# Altium schematic sheet ArduinoDevelopBoard-v2.SchDoc
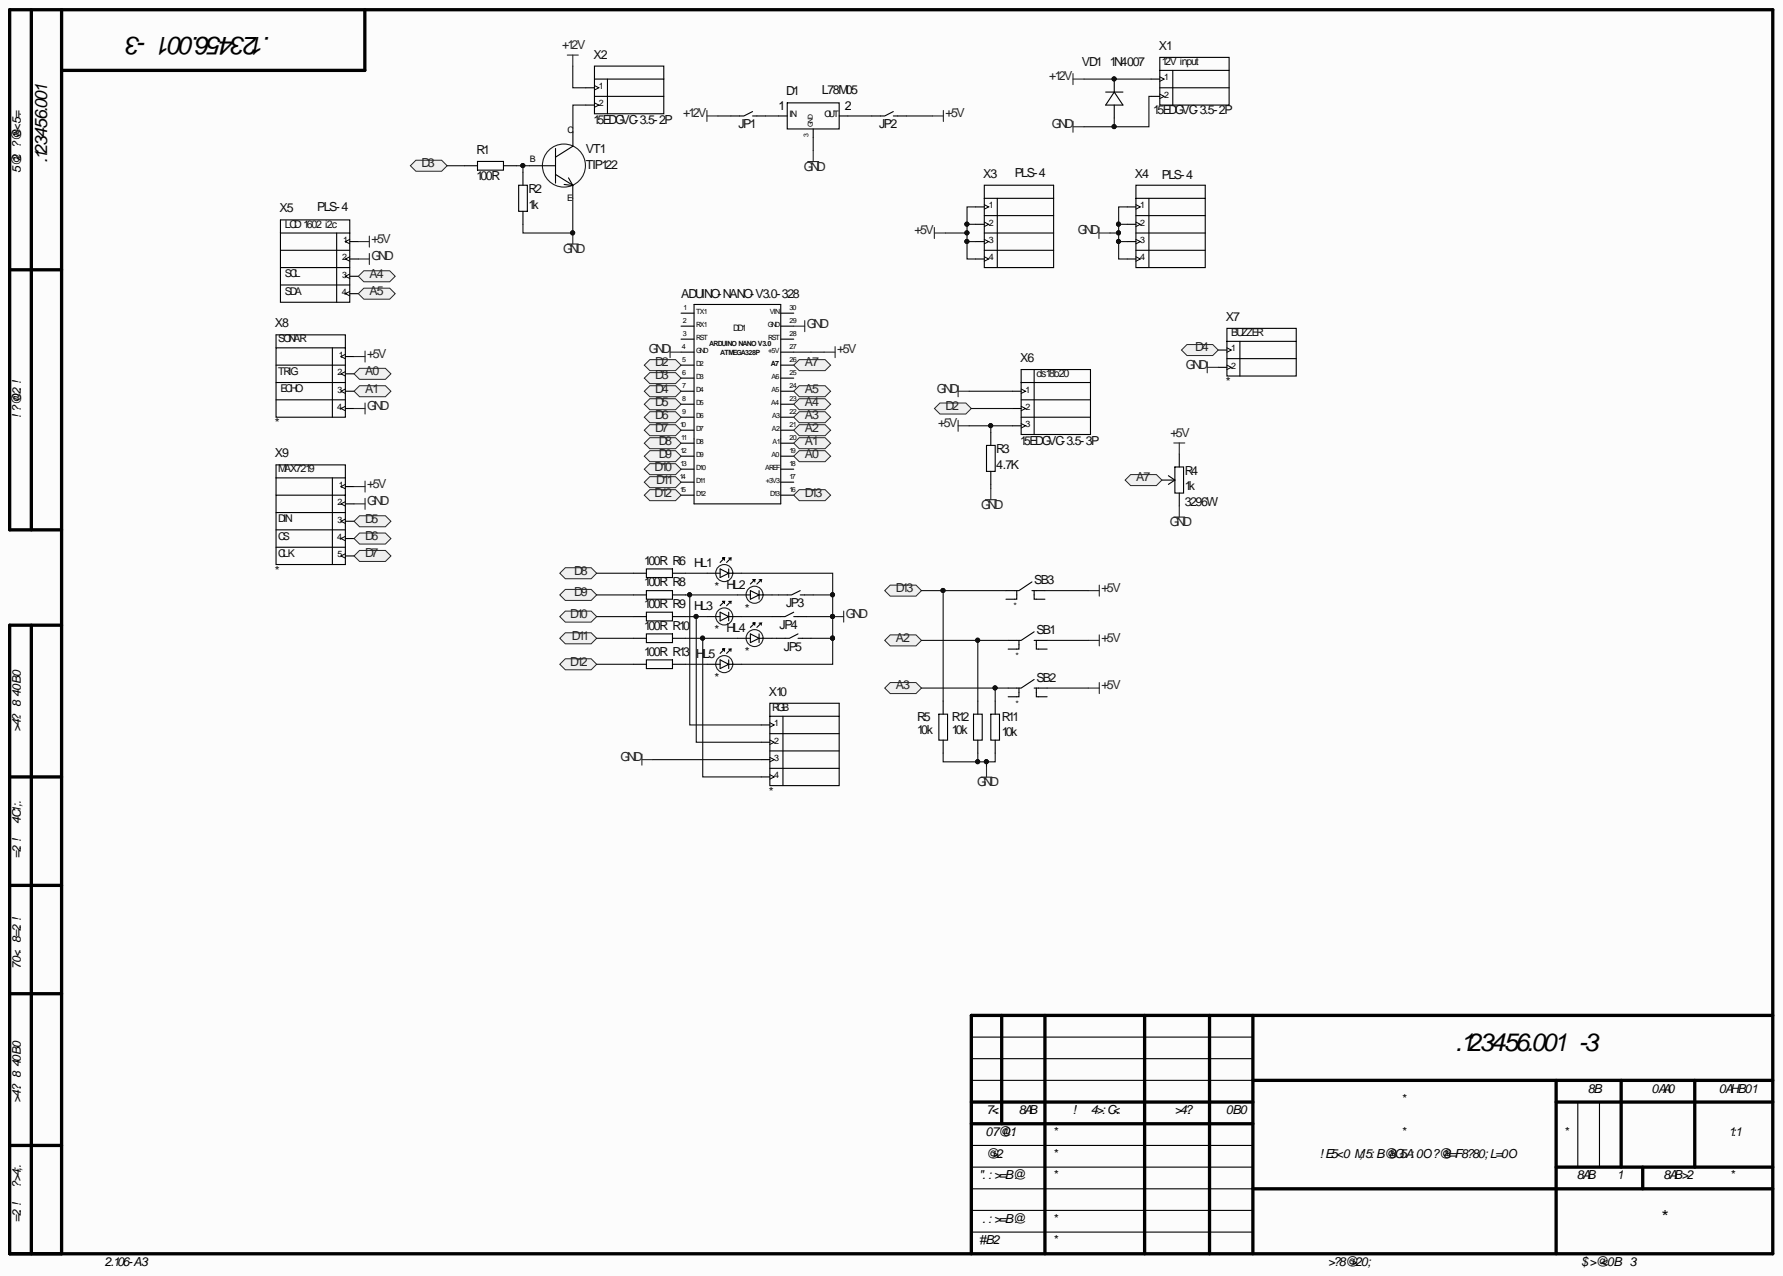
[diagram p0: ArduinoDevelopBoard-v2.SchDoc]

## Parts
D1  L78M05  [D-PAK]
DD1  ADUINO-NANO-V3.0-328  [ARDUINO_NANO_V3.0]
HL1  (no value)  [Led Diode 5mm]
HL2  (no value)  [Led Diode 5mm]
HL3  (no value)  [Led Diode 5mm]
HL4  (no value)  [Led Diode 5mm]
HL5  (no value)  [Led Diode 5mm]
JP1  Jumper  [PLS-2]  (value not drawn)
JP2  Jumper  [PLS-2]  (value not drawn)
JP3  Jumper  [PLS-2]  (value not drawn)
JP4  Jumper  [PLS-2]  (value not drawn)
JP5  Jumper  [PLS-2]  (value not drawn)
R1  100R  [Resistor-0.25W]
R2  1k  [Resistor-0.25W]
R3  4.7K  [Resistor-0.25W]
R4  1k  [3296W_Resistor]
R5  10k  [Resistor-0.25W]
R6  100R  [Resistor-0.25W]
R8  100R  [Resistor-0.25W]
R9  100R  [Resistor-0.25W]
R10  100R  [Resistor-0.25W]
R11  10k  [Resistor-0.25W]
R12  10k  [Resistor-0.25W]
R13  100R  [Resistor-0.25W]
SB1  (no value)  [KLS7-TS6601-5.0-180]
SB2  (no value)  [KLS7-TS6601-5.0-180]
SB3  (no value)  [KLS7-TS6601-5.0-180]
VD1  1N4007  [Diode (DO41)]
VT1  TIP122  [TO220]
X1  15EDGVC-3.5-2P  [15EDGVC-3.5-2P]
X2  15EDGVC-3.5-2P  [15EDGVC-3.5-2P]
X3  PLS-4  [PLS-4]
X4  PLS-4  [PLS-4]
X5  PLS-4  [PLS-4]
X6  15EDGVC-3.5-3P  [PLS-3]
X7  (no value)  [PLS-2]
X8  (no value)  [PLS-4]
X9  (no value)  [PLS-5]
X10  (no value)  [PLS-4]

## Ports
A0, A1, A2, A3, A4, A5, A7, D2, D3, D4, D5, D6, D7, D8, D9, D10, D11, D12, D13

## Nets
+5V: DD1.27(+5V) JP2.2 R3.2 R4.3 SB1.3 SB2.3 SB3.3 X3.1 X3.2 X3.3 X3.4 X5.1 X6.3 X8.1 X9.1
+12V: JP1.1 VD1.2 X1.1 X2.1
GND: D1.3 DD1.4(GND) DD1.29(GND) HL1.2 HL5.2 JP3.2 JP4.2 JP5.2 R2.2 R3.1 R4.1 R5.1 R11.1 R12.1 VD1.1 VT1.E X1.2 X4.1 X4.2 X4.3 X4.4 X5.2 X6.1 X7.2 X8.4 X9.2 X10.3
A0: DD1.19(A0) X8.2 ; port A0
A1: DD1.20(A1) X8.3 ; port A1
A2: DD1.21(A2) R12.2 SB1.1 ; port A2
A3: DD1.22(A3) R11.2 SB2.1 ; port A3
A4: DD1.23(A4) X5.3 ; port A4
A5: DD1.24(A5) X5.4 ; port A5
A7: DD1.26(A7) R4.2 ; port A7
D2: DD1.5(D2) X6.2 ; port D2
D3: DD1.6(D3) R1.2 ; port D3
D4: DD1.7(D4) X7.1 ; port D4
D5: DD1.8(D5) X9.3 ; port D5
D6: DD1.9(D6) X9.4 ; port D6
D7: DD1.10(D7) X9.5 ; port D7
D8: DD1.11(D8) R6.1 ; port D8
D9: DD1.12(D9) R8.1 ; port D9
D10: DD1.13(D10) R9.1 ; port D10
D11: DD1.14(D11) R10.1 ; port D11
D12: DD1.15(D12) R13.1 ; port D12
D13: DD1.16(D13) R5.2 SB3.1 ; port D13
NetD1_1: D1.1 JP1.2
NetD1_2: D1.2 JP2.1
NetHL1_1: HL1.1 R6.2
NetHL2_1: HL2.1 R8.2 X10.1
NetHL2_2: HL2.2 JP3.1
NetHL3_1: HL3.1 R9.2 X10.2
NetHL3_2: HL3.2 JP4.1
NetHL4_1: HL4.1 R10.2 X10.4
NetHL4_2: HL4.2 JP5.1
NetHL5_1: HL5.1 R13.2
NetR1_1: R1.1 R2.1 VT1.B
NetVT1_C: VT1.C X2.2

Not connected: DD1 1(TX1) 2(RX1) 3(RST) 17(+3V3) 18(AREF) 25(A6) 28(RST) 30(VIN); SB1 2 4; SB2 2 4; SB3 2 4

## Library data of the parts
DD1 = ARDUINO-NANO-V3.0-328: Component Kind: Standard; Library Name: arduino.IntLib
HL1-HL5 = Led-5mm: Component Kind: Standard; Library Name: Diodes.IntLib
R1-R3 R5 R11 R12 = Resistor 0.25W: =Power; Component Kind: Standard; Library Name: Basic.IntLib
  R1: Value: 100R
  R2: Value: 1k
  R3: Value: 4.7K
  R5 R11 R12: Value: 10k
R4 = 3296W: 3296; Case: 3296W; Component Kind: Standard; Library Name: Basic.IntLib; Value: 1k
R6 R8-R10 R13 = Resistor 0.25W: =Power; Value: 240R; Component Kind: Standard; Library Name: Basic.IntLib
SB1-SB3 = KLS7-TS6601-5.0-180: Component Kind: Standard; Library Name: Basic.IntLib
VD1 = 1N4007: 1A, 1000V; Component Kind: Standard; Library Name: Diodes.IntLib
VT1 = TIP122: PNP Transistor; Component Kind: Standard; Library Name: transistors.IntLib
X1 X2 X7 = Connector-2Pin: Component Kind: Standard; Library Name: connectors.IntLib
  X1 X2: Case: 15EDGVC-3.5-2P
X3-X5 X8 X10 = Connector-4Pin: Component Kind: Standard; Library Name: connectors.IntLib
  X3-X5: Case: PLS-4
X6 = Connector-3Pin: Case: 15EDGVC-3.5-3P; Component Kind: Standard; Library Name: connectors.IntLib
X9 = Connector-5Pin: Component Kind: Standard; Library Name: connectors.IntLib
D1 = L78M05: Component Kind: Standard; Description: L78M05; Library Name: misc.IntLib
JP1-JP5 = Jumper: Component Kind: Standard; Library Name: connectors.IntLib
